# Altium schematic sheet I_PRV_UWS_B2_1_0_MCU.SchDoc
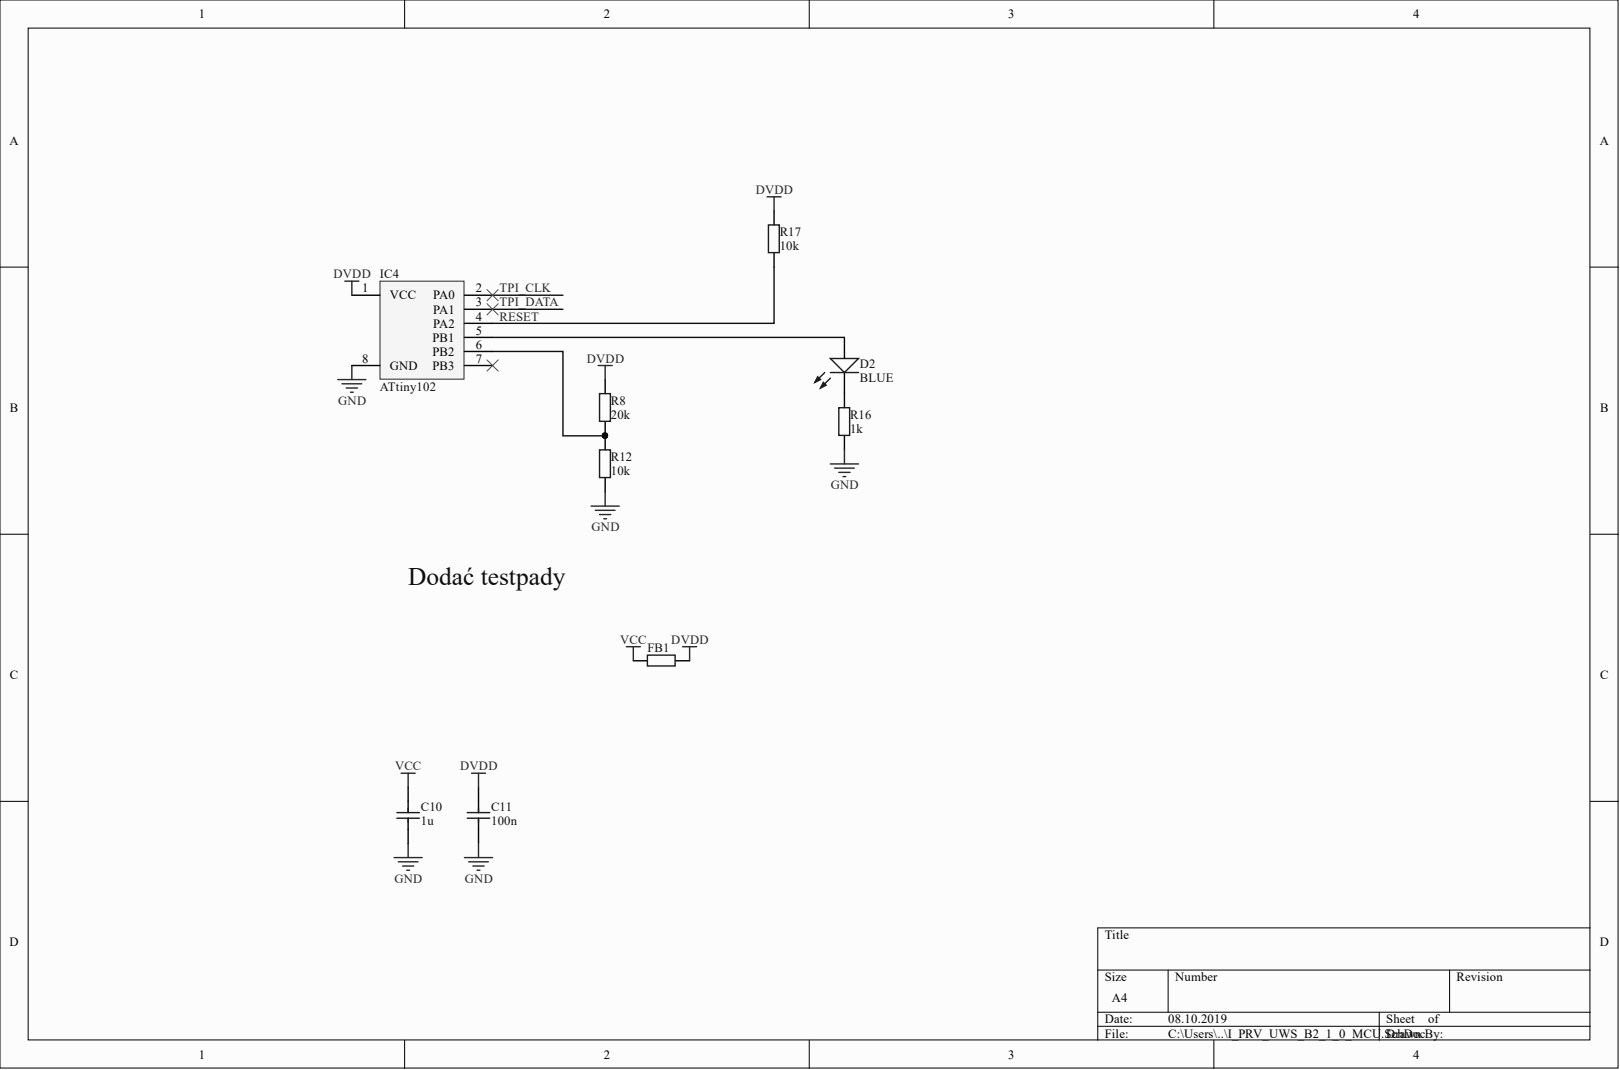
[diagram p0: I_PRV_UWS_B2_1_0_MCU.SchDoc]

## Parts
C10  value 1u  [SMD0402_CAP]  (hidden comment: Capacitor)
C11  value 100n  [SMD0402_CAP]  (hidden comment: Capacitor)
D2  value BLUE  [SMD0603_LED]  (hidden comment: LED)
FB1  Resistor  [SMD0402_RES]  (value not drawn)
IC4  ATtiny102  [SOIC_8]
R8  value 20k  [SMD0402_RES]  (hidden comment: Resistor)
R12  value 10k  [SMD0402_RES]  (hidden comment: Resistor)
R16  value 1k  [SMD0402_RES]  (hidden comment: Resistor)
R17  value 10k  [SMD0402_RES]  (hidden comment: Resistor)

## Nets
DVDD: C11.2 FB1.1 IC4.1(VCC) R8.2 R17.2
GND: C10.1 C11.1 IC4.8(GND) R12.1 R16.2
VCC: C10.2 FB1.2
RESET: IC4.4(PA2) R17.1
TPI_CLK: IC4.2(PA0)
TPI_DATA: IC4.3(PA1)
NetD2_1: D2.1 R16.1
NetD2_2: D2.2 IC4.5(PB1)
NetIC4_6: IC4.6(PB2) R8.1 R12.2

Not connected: IC4 7(PB3)

## Library data of the parts
IC4 = ATtiny102: ATtiny102 AVR uC; Component Kind: Standard; Library Name: BM_SCH_LIB.SchLib; Value: ATtiny102
C10 C11 = Capacitor: Component Kind: Standard; Description: Capacitor; Library Name: KD_SCH_LIB.SchLib
  C10: LCSC Part #: C52923
  C11: LCSC Part #: C1525
D2 = LED: Component Kind: Standard; Description: LED; LCSC Part #: C72041; Library Name: KD_SCH_LIB.SchLib
FB1 R8 R12 R16 R17 = Resistor: Component Kind: Standard; Description: Resistor; Library Name: KD_SCH_LIB.SchLib
  FB1: LCSC Part #: C25086
  R8: LCSC Part #: C25765
  R12 R17: LCSC Part #: C25744
  R16: LCSC Part #: C11702
